# Altium schematic sheet nRF52840-QIAA_revB.SchDoc(nRF52840-QIAA_revB)
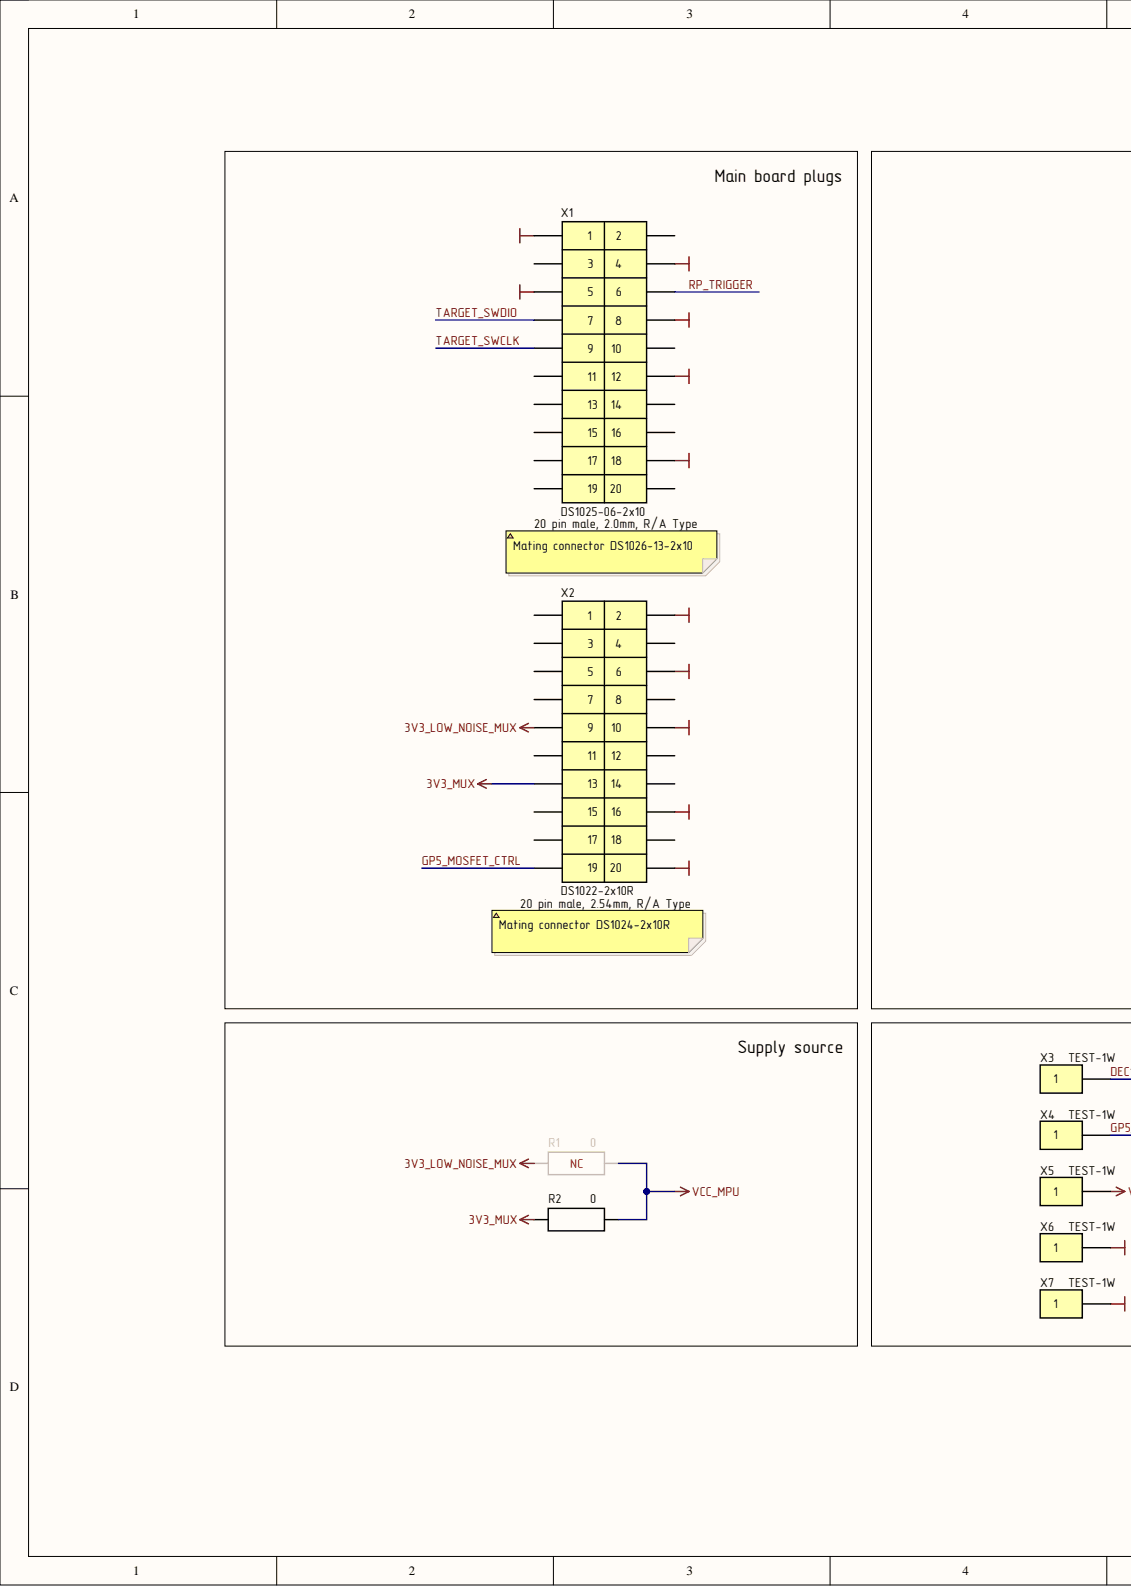
[diagram p0: nRF52840-QIAA_revB.SchDoc, left]
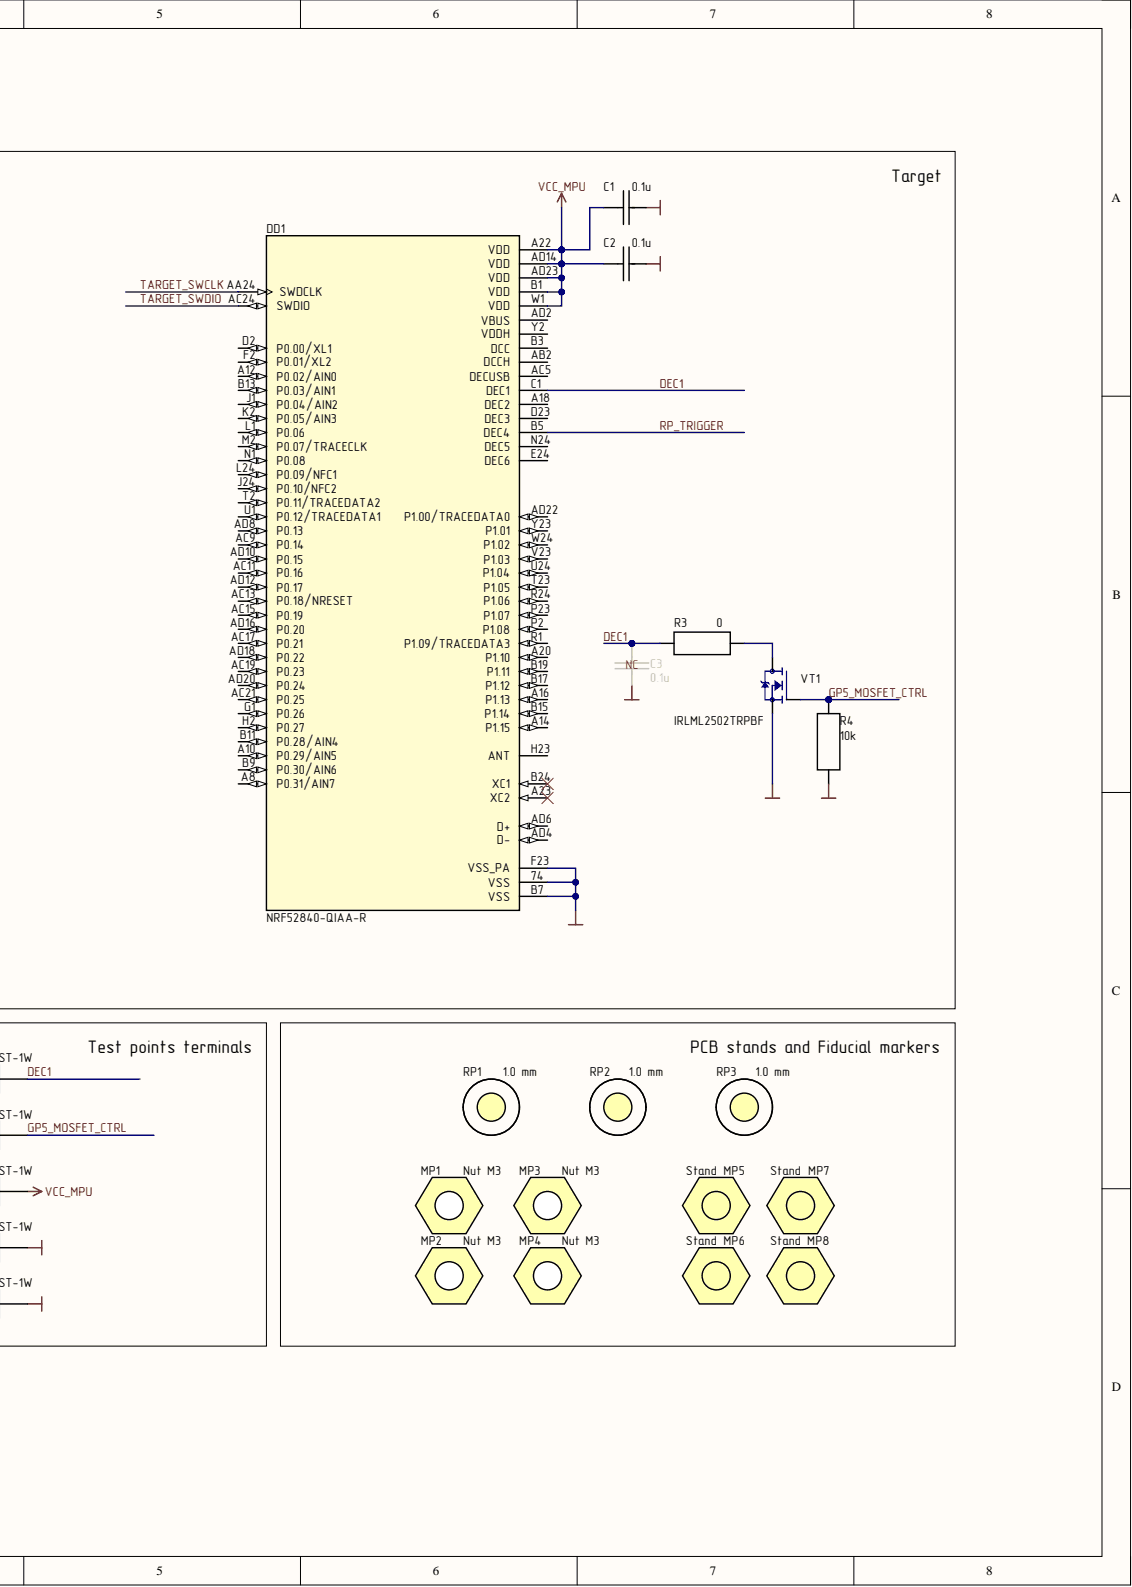
[diagram p1: nRF52840-QIAA_revB.SchDoc, right]

## Parts
C1  value 0.1u  [CH0603C]  (hidden comment: Ceramic capacitor, 0603, 0.1u, ±10%, 50V, X7R, -55...+125°C)
C2  value 0.1u  [CH0603C]  (hidden comment: Ceramic capacitor, 0603, 0.1u, ±10%, 50V, X7R, -55...+125°C)
C3  value 0.1u  [CH0603C]  (hidden comment: Ceramic capacitor, 0603, 0.1u, ±10%, 50V, X7R, -55...+125°C)
DD1  NRF52840-QIAA-R  [NRF52840-QIAA-R]
MP1  Nut M3  [Stand]  (mechanical)
MP2  Nut M3  [Stand]  (mechanical)
MP3  Nut M3  [Stand]  (mechanical)
MP4  Nut M3  [Stand]  (mechanical)
MP5  Stand  [Stand]
MP6  Stand  [Stand]
MP7  Stand  [Stand]
MP8  Stand  [Stand]
R1  value 0  [CH0603R]  (hidden comment: Resistor thick-film, 0603, 0, ±1%, 0.1W, -55...+125°C)
R2  value 0  [CH0603R]  (hidden comment: Resistor thick-film, 0603, 0, ±1%, 0.1W, -55...+125°C)
R3  value 0  [CH0603R]  (hidden comment: Resistor thick-film, 0603, 0, ±1%, 0.1W, -55...+125°C)
R4  value 10k  [CH0603R]  (hidden comment: Resistor thick-film, 0603, 10k, ±1%, 0.1W, -55...+125°C)
RP1  1.0 mm  [REPER-10]  (no BOM)
RP2  1.0 mm  [REPER-10]  (no BOM)
RP3  1.0 mm  [REPER-10]  (no BOM)
VT1  IRLML2502TRPBF  [SOT23]
X1  DS1025-06-2x10  [DS1025-06-2x10]
X2  DS1022-2x10R  [DS1022-2x10R]
X3  DEC1  PartNumber: TEST-1W  [TEST-1W 1pin MULTICOMP]
X4  GP5  PartNumber: TEST-1W  [TEST-1W 1pin MULTICOMP]
X5  VCC_MPU  PartNumber: TEST-1W  [TEST-1W 1pin MULTICOMP]
X6  PartNumber: TEST-1W  [TEST-1W 1pin MULTICOMP]  (hidden comment: GND)
X7  PartNumber: TEST-1W  [TEST-1W 1pin MULTICOMP]  (hidden comment: GND)

## Nets
3V3_LOW_NOISE_MUX: R1.2 X2.9
3V3_MUX: R2.2 X2.13
GND: C1.1 C2.1 C3.1 DD1.74(VSS) DD1.B7(VSS) DD1.F23(VSS_PA) R4.2 VT1.2 X1.1 X1.4 X1.5 X1.8 X1.12 X1.18 X2.2 X2.6 X2.10 X2.16 X2.20 X6.1 X7.1
VCC_MPU: C1.2 C2.2 DD1.A22(VDD) DD1.AD14(VDD) DD1.AD23(VDD) DD1.B1(VDD) DD1.W1(VDD) R1.1 R2.1 X5.1
DEC1: C3.2 DD1.C1(DEC1) R3.2 X3.1
GP5_MOSFET_CTRL: R4.1 VT1.1 X2.19 X4.1
RP_TRIGGER: DD1.B5(DEC4) X1.6
TARGET_SWCLK: DD1.AA24(SWDCLK) X1.9
TARGET_SWDIO: DD1.AC24(SWDIO) X1.7
NetR3_1: R3.1 VT1.3

Not connected: DD1 A8(P0.31/AIN7) A10(P0.29/AIN5) A12(P0.02/AIN0) A14(P1.15) A16(P1.13) A18(DEC2) A20(P1.10) A23(XC2) AB2(DCCH) AC5(DECUSB) AC9(P0.14) AC11(P0.16) AC13(P0.18/NRESET) AC15(P0.19) AC17(P0.21) AC19(P0.23) AC21(P0.25) AD2(VBUS) AD4(D-) AD6(D+) AD8(P0.13) AD10(P0.15) AD12(P0.17) AD16(P0.20) AD18(P0.22) AD20(P0.24) AD22(P1.00/TRACEDATA0) B3(DCC) B9(P0.30/AIN6) B11(P0.28/AIN4) B13(P0.03/AIN1) B15(P1.14) B17(P1.12) B19(P1.11) B24(XC1) D2(P0.00/XL1) D23(DEC3) E24(DEC6) F2(P0.01/XL2) G1(P0.26) H2(P0.27) H23(ANT) J1(P0.04/AIN2) J24(P0.10/NFC2) K2(P0.05/AIN3) L1(P0.06) L24(P0.09/NFC1) M2(P0.07/TRACECLK) N1(P0.08) N24(DEC5) P2(P1.08) P23(P1.07) R1(P1.09/TRACEDATA3) R24(P1.06) T2(P0.11/TRACEDATA2) T23(P1.05) U1(P0.12/TRACEDATA1) U24(P1.04) V23(P1.03) W24(P1.02) Y2(VDDH) Y23(P1.01); MP5 1; MP6 1; MP7 1; MP8 1; RP1 1; RP2 1; RP3 1; X1 2 3 10 11 13 14 15 16 17 19 20; X2 1 3 4 5 7 8 11 12 14 15 17 18

## Library data of the parts
C1-C3 = Capacitor: Manufacturer: Murata; PartNumber: GRM188R71H104K; Type: SMD; Component Kind: Standard; ComponentType: Ceramic capacitor; Description/Value: Ceramic capacitor, 0603, 0.1u, ±10%, 50V, X7R, -55...+125°C; HelpURL: ?; Library Name: Common Lib.SchLib; Package: 0603; Temperature: -55...+125°C; Tolerance: ±10%; TypeDielectric: X7R; Voltage: 50V
DD1 = CMP-00113-3: IC RF TxRx + MCU 802.15.4, Bluetooth Bluetooth v5.0, Thread, ZigbeeÂ® 2.4GHz 73-VFQFN Dual Rows, Exposed Pad; Component Kind: Standard; Description/Value: IC RF TxRx + MCU 802.15.4, Bluetooth Bluetooth v5.0; Package: VFQFN-73 Nordic; PartNumber: NRF52840-QIAA-R; Library Name: Positive Labs Server
MP1-MP4 = nut: PartNumber: Nut M3 DIN 934; Description/Value: Hexagon Nut M3 DIN 934; Library Name: Common Lib.SchLib
MP5-MP8 = stand: PartNumber: PCHSN-10; Description/Value: PCB brass stand, M3, 10mm; Component Kind: Standard; Library Name: Common Lib.SchLib
R1-R3 = Resistor: Manufacturer: Yageo; PartNumber: RC0603FR-070RL; Component Kind: Standard; ComponentType: Resistor thick-film; Description/Value: Resistor thick-film, 0603, 0, ±1%, 0.1W, -55...+125°C; HelpURL: https://datasheet.lcsc.com/lcsc/2009261810_YAGEO-RL0805FR-070R33L_C724024.pdf; Library Name: Common Lib.SchLib; Package: 0603; Power: 0.1W; Temperature: -55...+125°C; Tolerance: ±1%; Type: SMD
R4 = Resistor: Manufacturer: Yageo; PartNumber: RC0603FR-0710KL; Component Kind: Standard; ComponentType: Resistor thick-film; Description/Value: Resistor thick-film, 0603, 10k, ±1%, 0.1W, -55...+125°C; HelpURL: https://datasheet.lcsc.com/lcsc/2009261810_YAGEO-RL0805FR-070R33L_C724024.pdf; Library Name: Common Lib.SchLib; Package: 0603; Power: 0.1W; Temperature: -55...+125°C; Tolerance: ±1%; Type: SMD
RP1-RP3 = CMP-00005-2: Design Item ID: REPER; Source: Common Lib.SchLib; Library Name: Positive Labs Server
VT1 = MOSFET N: RoHS Compliant | Industry-leading quality | Fast Switching | Low Profile _less than 1.1mm_ | SOT-23 Footprint; Description/Value: N-MOSFET Transistor, 20V, 4.2A, SOT-23-23; Component Kind: Standard; Library Name: Common Lib.SchLib; Package: SOT-23; PartNumber: IRLML2502TRPBF
X1 = 20-Connector: Description/Value: PLD Connector 20 pin, 2.0mm, Male, DS1025-06-2x10; Component Kind: Standard; ComponentKind: PLD Connector 20 pin, 2.0mm, Male; Library Name: Common Lib.SchLib; PartNumber: DS1025-06-2x10
X2 = 20-Connector: Description/Value: PLD Connector 20 pin, 2.54mm, Male, DS1022-2x10R; Component Kind: Standard; ComponentKind: PLD Connector 20 pin, 2.54mm, Male; Library Name: Common Lib.SchLib; PartNumber: DS1022-2x10R
X3-X7 = 1-Connector: Description/Value: PCB Test Point Through Hole Mount; Component Kind: Standard; Library Name: Common Lib.SchLib
